# Altium schematic sheet ATtiny85_DevBoard_RevA.SchDoc
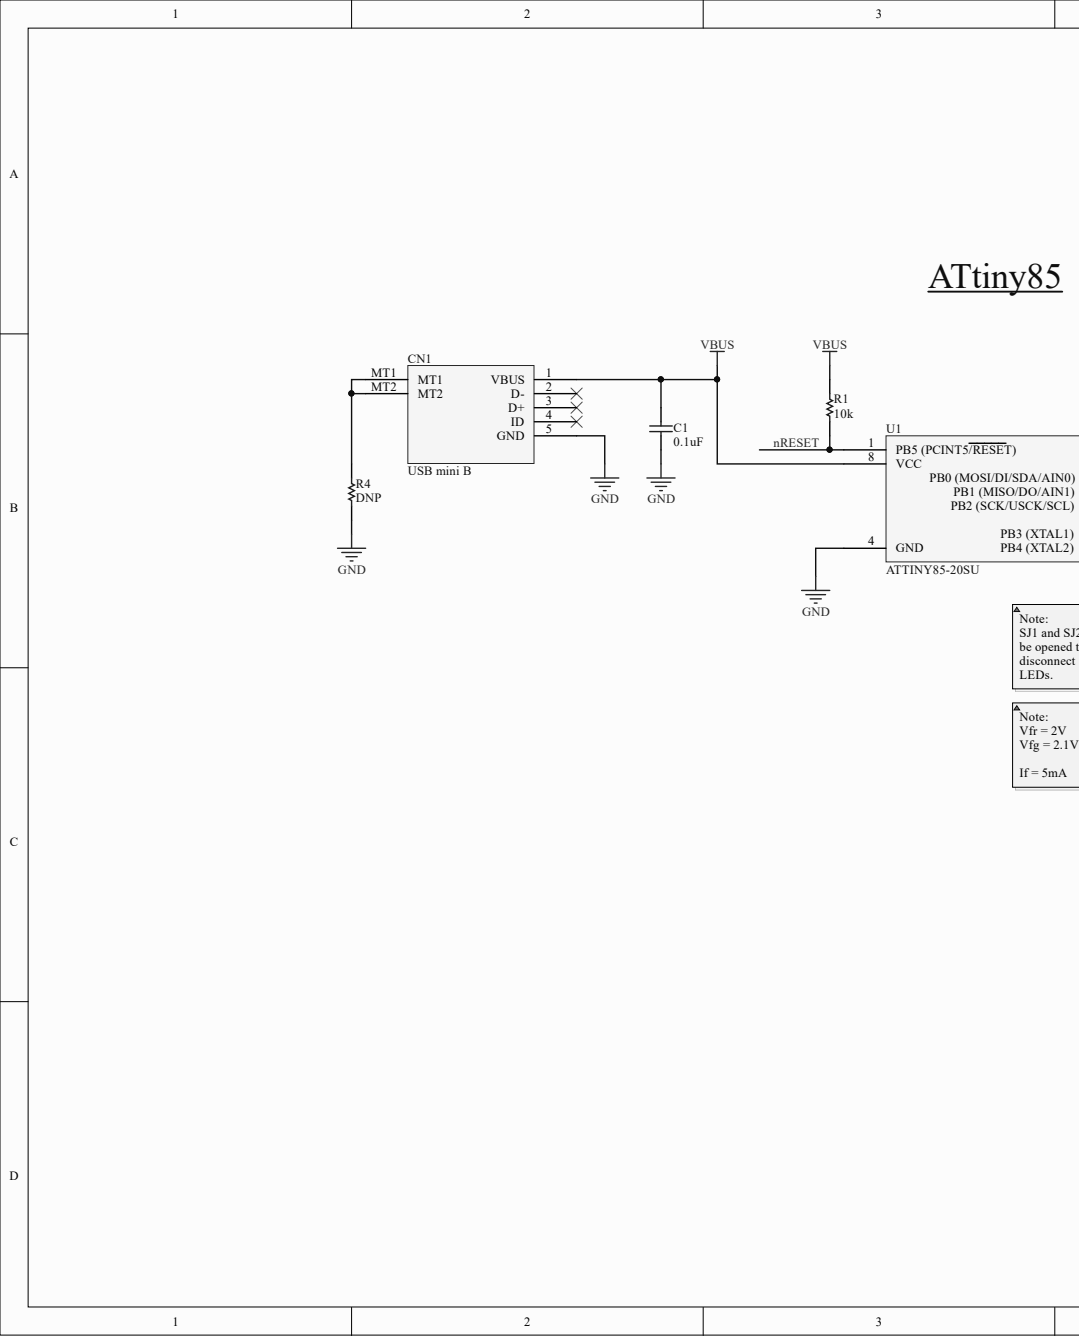
[diagram p0: ATtiny85_DevBoard_RevA.SchDoc, left]
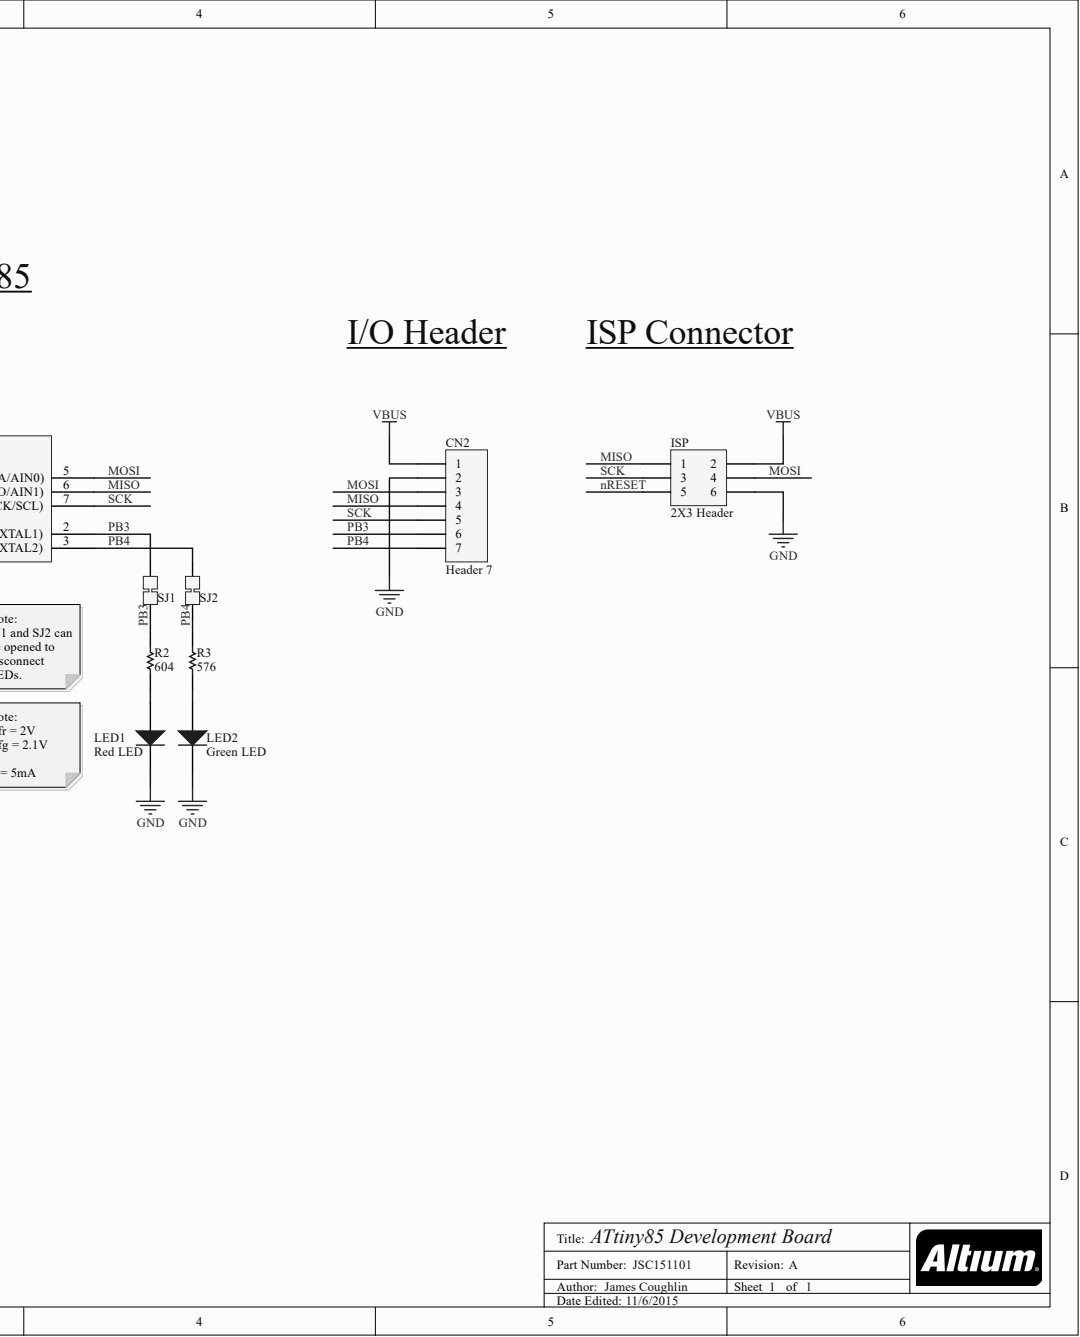
[diagram p1: ATtiny85_DevBoard_RevA.SchDoc, right]

## Parts
C1  value 0.1uF  [0805]
CN1  value USB mini B  [0548190519]  (hidden comment: 0548190519)
CN2  value Header 7  [1X7 HEADER]
ISP  value 2X3 Header  [2X3POS HEADER]
LED1  value Red LED  [0805]
LED2  value Green LED  [0805]
R1  value 10k  [0805]
R2  value 604  [0805]
R3  value 576  [0805]
R4  value DNP  [0805]
SJ1  Solder Jumper  [Solder Jumper]  (value not drawn)  (jumper)
SJ2  Solder Jumper  [Solder Jumper]  (value not drawn)  (jumper)
U1  value ATTINY85-20SU  [SOIC127P800X216-8N]

## Nets
GND: C1.1 CN1.5(GND) CN2.2 ISP.6 LED1.2 LED2.2 R4.1 U1.4(GND)
VBUS: C1.2 CN1.1(VBUS) CN2.1 ISP.2 R1.1 U1.8(VCC)
MISO: CN2.4 ISP.1 U1.6(PB1_(MISO/DO/AIN1))
MOSI: CN2.3 ISP.4 U1.5(PB0_(MOSI/DI/SDA/AIN0))
NRESET: ISP.5 R1.2 U1.1(PB5_(PCINT5/RESET))
PB3: CN2.6 R2.2 U1.2(PB3_(XTAL1))
PB4: CN2.7 R3.2 U1.3(PB4_(XTAL2))
SCK: CN2.5 ISP.3 U1.7(PB2_(SCK/USCK/SCL))
NetCN1_MT1: CN1.MT1 CN1.MT2 R4.2
NetLED1_1: LED1.1 R2.1
NetLED2_1: LED2.1 R3.1

Not connected: CN1 2(D-) 3(D+) 4(ID); SJ1 1; SJ2 1

## Library data of the parts
C1 = Cap: CAP CER 0.1UF 25V X7R 0805; Manufacturer 1: Murata Electronics North America; Manufacturer Part Number 1: GRM21BR71E104KA01L; Component Kind: Standard; Library Name: Capacitors.SchLib; Signal Integrity: Cap; Simulation: CAP; Supplier 1: Digi-Key; Supplier Part Number 1: 490-1673-1-ND
CN1 = 0548190519: Connector Receptacle USB - mini B 2.0 OTG 5 Position Through Hole, Right Angle, Horizontal; Manufacturer 1: Molex, LLC; Component Kind: Standard; Library Name: Connectors.SchLib; Manufacturer Part Number 1: 0548190519; Supplier 1: Digi-Key; Supplier Part Number 1: WM17115-ND
CN2 = Header 7: Header, 7-Pin; Component Kind: Standard; Library Name: Connectors.SchLib; Signal Integrity: Connector
ISP = Header 2X3: 2X3POS DIL VERTICAL PIN HEADER; Manufacturer 1: Harwin Inc.; Manufacturer Part Number 1: M20-9720345; Component Kind: Standard; Library Name: Connectors.SchLib; Signal Integrity: Connector; Supplier 1: Digi-Key; Supplier Part Number 1: 952-1921-ND
LED1 = 0805_LED: LED RED CLEAR 0805 SMD; Manufacturer 1: Lite-On Inc.; Manufacturer Part Number 1: LTST-C171KRKT; Component Kind: Standard; Library Name: LEDs.SchLib; Supplier 1: Digi-Key; Supplier Part Number 1: 160-1427-1-ND
LED2 = 0805_LED: LED GREEN CLEAR 0805 SMD; Manufacturer 1: Lite-On Inc.; Manufacturer Part Number 1: LTST-C171GKT; Component Kind: Standard; Library Name: LEDs.SchLib; Supplier 1: Digi-Key; Supplier Part Number 1: 160-1423-1-ND
R1 = Res1: RES SMD 10K OHM 5% 1/8W 0805; Manufacturer 1: Yageo; Manufacturer Part Number 1: RC0805JR-0710KL; Component Kind: Standard; Library Name: Resistors.SchLib; Signal Integrity: Res; Simulation: RESISTOR; Supplier 1: Digi-Key; Supplier Part Number 1: 311-10KARCT-ND
R2 = Res1: RES SMD 604 OHM 1% 1/8W 0805; Manufacturer 1: Yageo; Manufacturer Part Number 1: RC0805FR-07604RL; Component Kind: Standard; Library Name: Resistors.SchLib; Signal Integrity: Res; Simulation: RESISTOR; Supplier 1: Digi-Key; Supplier Part Number 1: 311-604CRCT-ND
R3 = Res1: RES SMD 576 OHM 1% 1/8W 0805; Manufacturer 1: Yageo; Manufacturer Part Number 1: RC0805FR-07576RL; Component Kind: Standard; Library Name: Resistors.SchLib; Signal Integrity: Res; Simulation: RESISTOR; Supplier 1: Digi-Key; Supplier Part Number 1: 311-576CRCT-ND
R4 = Res1: RES SMD 5% 1/8W 0805; Component Kind: Standard; Library Name: Resistors.SchLib; Signal Integrity: Res; Simulation: RESISTOR
SJ1 SJ2 = Solder Jumper: Solder Jumper - Normally Closed; Library Name: Connectors.SchLib
U1 = ATTINY85-20SU: IC MCU 8BIT 8KB FLASH 8SOIC; Manufacturer 1: Atmel; Manufacturer Part Number 1: ATTINY85-20SU-ND; Component Kind: Standard; Library Name: ICs.SchLib; Supplier 1: Digi-Key; Supplier Part Number 1: ATTINY85-20SU-ND
